# Altium schematic sheet PowerRegulationBoardV2.SchDoc(PowerRegulationBoardV2)
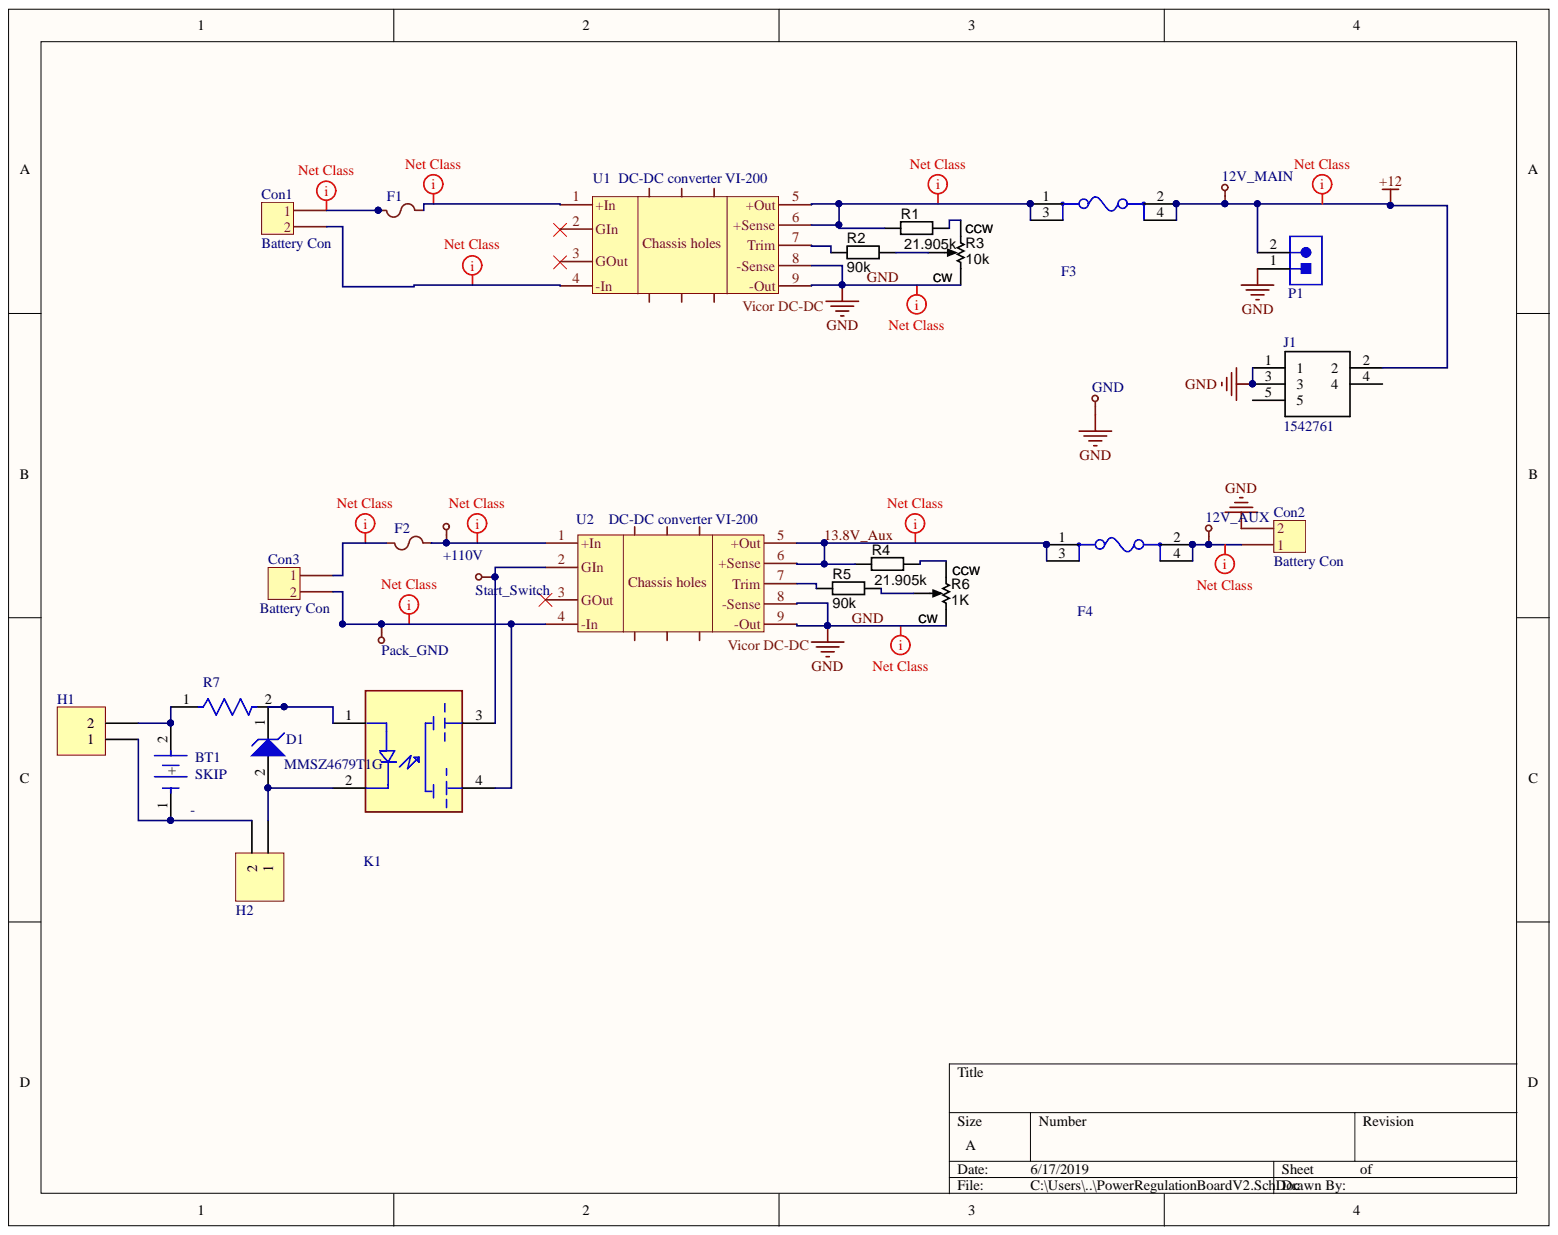
[diagram p0: PowerRegulationBoardV2.SchDoc]

## Parts
12V_AUX  Testpoint  [TestPoint]  (value not drawn)
12V_MAIN  Testpoint  [TestPoint]  (value not drawn)
+110V  Testpoint  [TestPoint]  (value not drawn)
BT1  Voltage: SKIP  [Keystone_1020_0]  (hidden comment: Batt_Keystone_1020_eec)
Con1  Battery Con  [Battery Con]
Con2  Battery Con  [Battery Con]
Con3  Battery Con  [Battery Con]
D1  MMSZ4679T1G  [ONSC-SOD-123-2-425-04_V]
F1  PC TRON Fuse 5A  [PC TRON 5A]  (value not drawn)
F2  PC TRON Fuse 5A  [PC TRON 5A]  (value not drawn)
F3  3557-2  [Keystone_3557-2_0]  (value not drawn)
F4  3557-2  [Keystone_3557-2_0]  (value not drawn)
GND  Testpoint  [TestPoint]  (value not drawn)
H1  Header 0  [HDR1X2]  (value not drawn)
H2  Header 0  [HDR1X2]  (value not drawn)
J1  1542761  [1542761]
K1  CPC1117N  [CPC1117N]  (value not drawn)
P1  SCREW-5.0/5.08 2WAYS  [SCREW-5.0-2WAYS_V]  (value not drawn)
Pack_GND  Testpoint  [TestPoint]  (value not drawn)
R1  value 21.905k  [Vishay_WSL2010_0]  (hidden comment: Thick Film)
R2  value 90k  [Vishay_WSL2010_0]  (hidden comment: Thick Film)
R3  value 10k  [TrimPot_THT_2.54mm pitch]  (hidden comment: 25 Turn)
R4  value 21.905k  [Vishay_WSL2010_0]  (hidden comment: Thick Film)
R5  value 90k  [Vishay_WSL2010_0]  (hidden comment: Thick Film)
R6  value 1K  [TrimPot_THT_2.54mm pitch]  (hidden comment: 25 Turn)
R7  CRCW201020R0FKEF  [Vishay_CRCW2010_IPC_B]  (value not drawn)
Start_Switch  Testpoint  [TestPoint]  (value not drawn)
U1  DC-DC converter VI-200  [Vicor DC-DC Maxi]
U2  DC-DC converter VI-200  [Vicor DC-DC Maxi]

## Nets
+12: 12V_MAIN.1 F3.2 F3.4 J1.2 P1.2
13.8V_Aux: F4.1 F4.3 R4.2 U2.5(+Out) U2.6(+Sense)
GND: Con2.2 GND.1 J1.1 J1.3 P1.1 R3.3 R6.3 U1.8(-Sense) U1.9(-Out) U2.8(-Sense) U2.9(-Out)
Net12V_AUX_1: 12V_AUX.1 Con2.1 F4.2 F4.4
Net+110V_1: +110V.1 F2.2 U2.1(+In)
NetBT1_1: BT1.1 H1.1 H2.2
NetBT1_2: BT1.2 H1.2 R7.1
NetCon1_1: Con1.1 F1.1
NetCon1_2: Con1.2 U1.4(-In)
NetCon3_1: Con3.1 F2.1
NetCon3_2: Con3.2 K1.4 Pack_GND.1 U2.4(-In)
NetD1_1: D1.1 K1.1 R7.2
NetD1_2: D1.2 H2.1 K1.2
NetF1_2: F1.2 U1.1(+In)
NetF3_1: F3.1 F3.3 R1.2 U1.5(+Out) U1.6(+Sense)
NetK1_3: K1.3 Start_Switch.1 U2.2(GIn)
NetR1_1: R1.1 R3.1
NetR2_1: R2.1 R3.2
NetR2_2: R2.2 U1.7(Trim)
NetR4_1: R4.1 R6.1
NetR5_1: R5.1 R6.2
NetR5_2: R5.2 U2.7(Trim)

Not connected: J1 4 5; U1 2(GIn) 3(GOut) 11 12 13 14 15; U2 3(GOut) 11 12 13 14 15

## Library data of the parts
BT1 = Batt_Keystone_1020_eec: BATT HOLDER BATTERY AAA SMD; Part Number: 1020; Temperature Range Low: -50°C; Temperature Range High: +145°C; Temperature: -50°C to +145°C; Supplier Part Number 2: 534-1020; Supplier Part Number 1: 36-1020-ND; Supplier 2: Mouser; Supplier 1: Digi-Key; RoHS: TRUE; Price: 1.62889830371; Package: BT_HLDR_SMT; Mouser Part Number: 534-1020; Manufacturer: Keystone; Library Name: Batt_Keystone_1020_eec.SchLib; Lead Free: yes; helpURL: https://upverter.com/upn/revision/aeadfed39ba6ac98/; Digikey Part Number: 36-1020-ND; Digikey Description: BATT HOLDER BATTERY AAA SMD; ComponentLink2URL: http://www.keyelco.com/product-pdf.cfm?p=934; ComponentLink2Description: Datasheet; ComponentLink1URL: https://upverter.com/upn/revision/aeadfed39ba6ac98/; ComponentLink1Description: Upverter; Component Kind: Standard
Con1-Con3 = Battery Con: Aux and Main battery connector; Library Name: Components_library.SchLib; Component Kind: Standard
D1 = MMSZ4679T1G: Zener Voltage Regulator, 500 mW, 2-Pin SOD-123, Pb-Free, Tape and Reel; Manufacturer: On Semiconductor; VZ Typ (V): 2; P Max (W): 0.5; RoHS: Pb-Free; PartNumber: MMSZ4679T1G; Packing: Tape and Reel; PackageVersion: Rev. G, 10/2009; PackageReference: SOD-123-2-425-04; PackageDescription: 2-Pin SOD, Body 1.6 x 2.69 mm; Mounting Technology: Surface Mount; Library Name: On_Semiconductor_MMSZ4679T1G.SchLib; DatasheetVersion: Rev. 9; ComponentLink3URL: http://www.onsemi.com/pub_link/Collateral/425-04.PDF; ComponentLink3Description: Package Specification; ComponentLink2URL: http://www.onsemi.com/pub_link/Collateral/MMSZ4678T1-D.PDF; ComponentLink2Description: Datasheet; ComponentLink1URL: http://www.onsemi.com/; ComponentLink1Description: Manufacturer URL; Component Kind: Standard
H1 H2 = Header 2: Header, 2-Pin; Signal Integrity: Connector; Library Name: Miscellaneous Connectors.IntLib; LatestRevisionNote: Re-released for DXP Platform.; LatestRevisionDate: 17-Jul-2002; Component Kind: Standard; Publisher: Altium Limited
J1 = 1542761: Connector; Manufacturer_Name: Phoenix Contact; Allied_Number: 70170366; RS Price/Stock: http://uk.rs-online.com/web/p/products/8025583; RS Part Number: 8025583; Mouser Price/Stock: https://www.mouser.com/Search/Refine.aspx?Keyword=651-1542761; Mouser Part Number: 651-1542761; Manufacturer_Part_Number: 1542761; Height: 18mm; Datasheet Link: https://media.digikey.com/PDF/Data%20Sheets/Phoenix%20Contact%20PDFs/1542761.pdf; Component Kind: Standard; Allied Price/Stock: https://www.alliedelec.com/phoenixcontact-1542761/70170366/
P1 = CMP-0617-00009-1: Socket, Screw Terminal Block, 2 Way, 5.0 mm Pitch, 90 Degree Angle, Through-Hole; Manufacturer: No Vendor; Rated Voltage: 300 V; Rated Current: 25 A; Poles: 2; Insulation Resistance: 5000 MOhm / 1000 V; Contact Resistance: 20 mOhm; Temperature Range: -40 to 105 degC; PartNumber: SCREW-5.0/5.08 2WAYS; PackageDescription: Socket, Screw Terminal Block, 2 Way, Pitch 5 mm, 90 Degree Angle, Through-Hole; Mounting Technology: Through Hole; Library Name: Altium Content Vault; ComponentLink1URL: 5mm screw terminal in SVN; ComponentLink1Description: Datasheet; Component Kind: Standard
R1 R4 = RES_10R_2010_5%: Resistor SMD chip 10 Ohm 0.25W 5% 2010; Manufacturer Part Number 1: CRCW120610R0JNEA; Manufacturer 1: Vishay/Dale; Value: 21.905k; SCDType: undef; Ratings: 1/4W; Tolerance: ±5%; Supplier Part Number 1: 541-10ECT-ND; Supplier 1: Digi-Key; Package / Case: 2010; Library Name: PBL - Resistors.SCHLIB; ComponentLink1URL: http://www.vishay.com/doc?20035; ComponentLink1Description: http://www.vishay.com/doc?20035; Component Kind: Standard
R2 R5 = RES_10R_2010_5%: Resistor SMD chip 10 Ohm 0.25W 5% 2010; Manufacturer Part Number 1: CRCW120610R0JNEA; Manufacturer 1: Vishay/Dale; SCDType: undef; Ratings: 1/4W; Tolerance: ±5%; Supplier Part Number 1: 541-10ECT-ND; Supplier 1: Digi-Key; Package / Case: 2010; Library Name: PBL - Resistors.SCHLIB; ComponentLink1URL: http://www.vishay.com/doc?20035; ComponentLink1Description: http://www.vishay.com/doc?20035; Component Kind: Standard
R3 R6 = RES_TRIMMER_1K_25T_THT: Trimmer, 25-Turn, 1K cermet; Manufacturer Part Number 1: PV36Y102C01B00; Manufacturer 1: Murata Electronics North America; Tolerance: 10%; SCDType: spec; Ratings: 25 turn variable cermet; Supplier Part Number 1: 490-2912-ND; Supplier 1: Digi-Key; Library Name: PBL - Resistors.SCHLIB; Component Kind: Standard
U1 U2 = DC-DC converter Maxi: Library Name: Components_library.SchLib; Component Kind: Standard
12V_AUX 12V_MAIN +110V GND Pack_GND Start_Switch = Testpoint: Library Name: Components_library.SchLib; Component Kind: Standard
F1 F2 = PC TRON Fuse 5A: Library Name: Components_library.SchLib; Component Kind: Standard
F3 F4 = 3557-2: Library Name: parts/Fuse_Keystone_3557-2_eec.SchLib; Component Kind: Standard
K1 = CPC1117N: Library Name: CPC1117N.SchLib; Component Kind: Standard
R7 = CRCW201020R0FKEF: Library Name: parts/Res_Vishay_CRCW201020R0FKEF_eec.SchLib; Component Kind: Standard
